# Altium schematic sheet ARK_Flow_External_Interfaces_Power.SchDoc(ARK_Flow_External_Interfaces_Power)
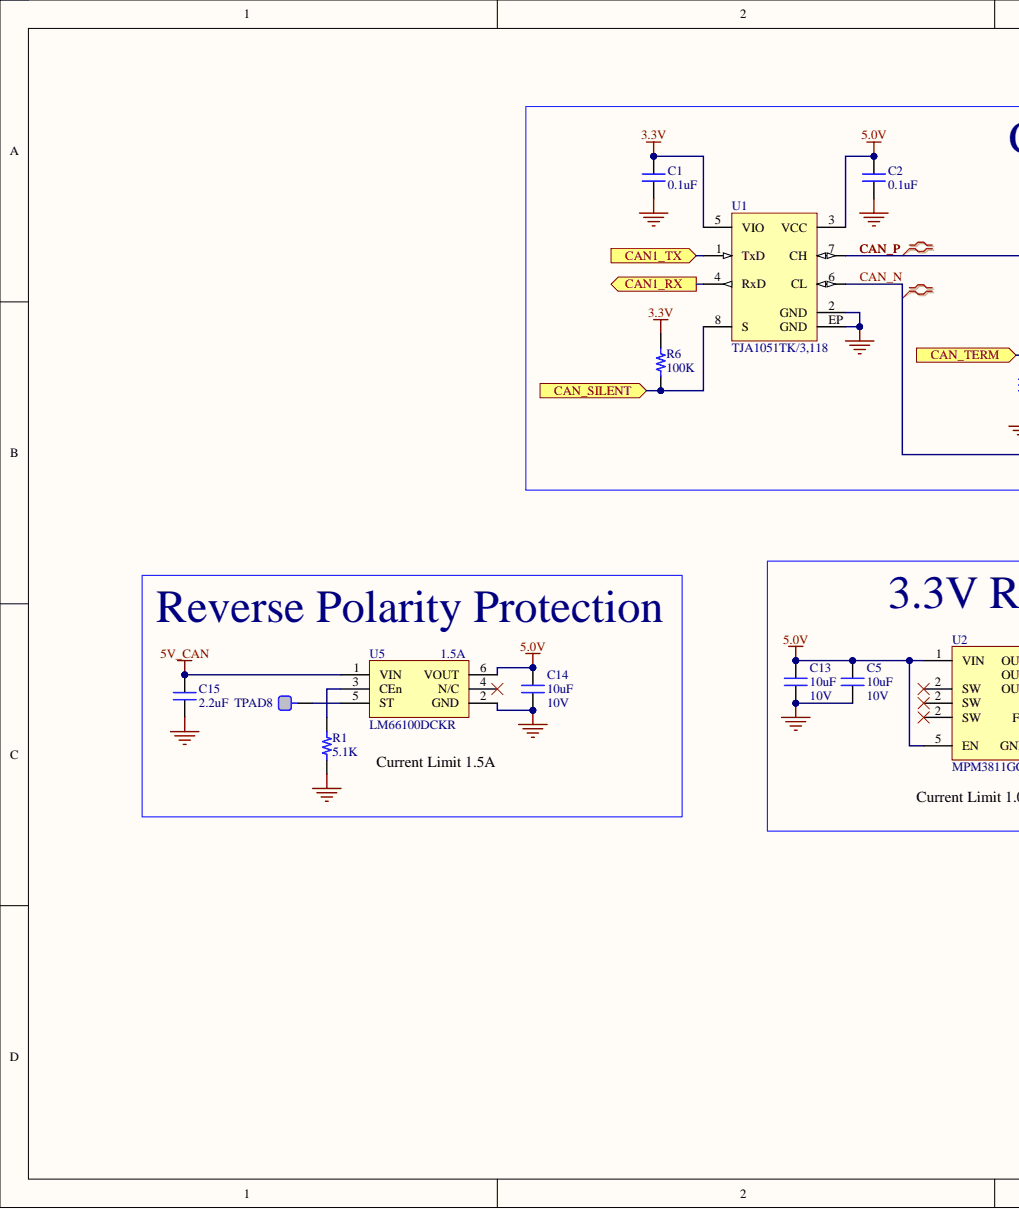
[diagram p0: ARK_Flow_External_Interfaces_Power.SchDoc, left]
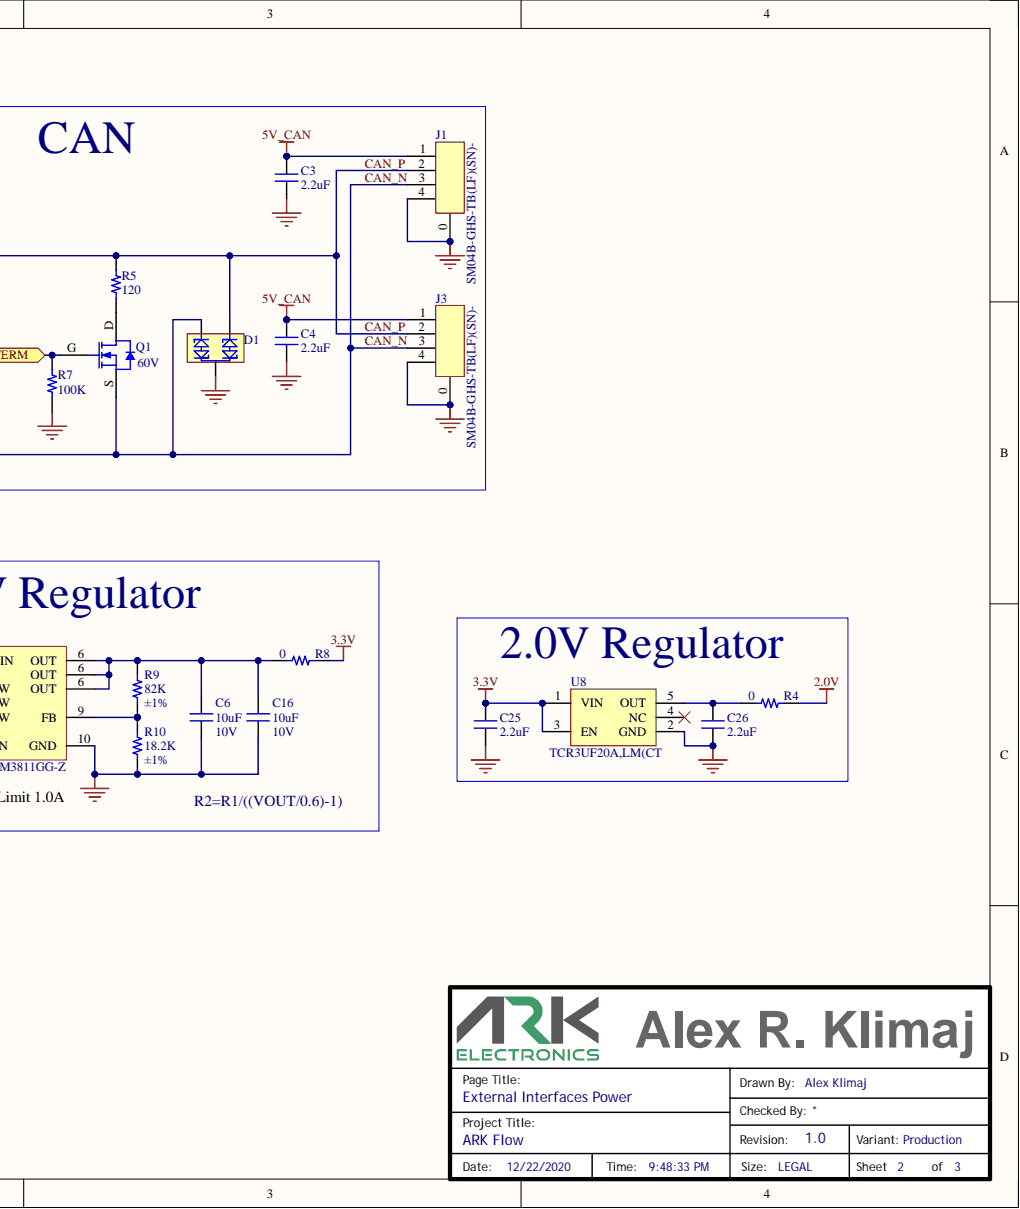
[diagram p1: ARK_Flow_External_Interfaces_Power.SchDoc, right]

## Parts
C1  value 0.1uF  [CAP 0402/1005]
C2  value 0.1uF  [CAP 0402/1005]
C3  value 2.2uF  [CAP 0402/1005]  (hidden comment: Capacitor)
C4  value 2.2uF  [CAP 0402/1005]  (hidden comment: Capacitor)
C5  10uF  Voltage_Rating: 10V  [CAP 0402/1005]
C6  10uF  Voltage_Rating: 10V  [CAP 0402/1005]
C13  10uF  Voltage_Rating: 10V  [CAP 0402/1005]
C14  10uF  Voltage_Rating: 10V  [CAP 0402/1005]
C15  value 2.2uF  [CAP 0402/1005]  (hidden comment: Capacitor)
C16  10uF  Voltage_Rating: 10V  [CAP 0402/1005]
C25  value 2.2uF  [CAP 0402/1005]  (hidden comment: Capacitor)
C26  value 2.2uF  [CAP 0402/1005]  (hidden comment: Capacitor)
D1  PESD1CAN-UX  [SOT65P210X110-3N]  (value not drawn)
J1  value SM04B-GHS-TB(LF)(SN)-  Mfr Part Number: SM04B-GHS-TB(LF)(SN)-  [SM04B-GHS]
J3  value SM04B-GHS-TB(LF)(SN)-  Mfr Part Number: SM04B-GHS-TB(LF)(SN)-  [SM04B-GHS]
Q1  Voltage_Rating: 60V  [SOT90P240X110-3N]  (hidden comment: DMN67D7L-7)
R1  value 5.1K  [RESC0402(1005)_N]
R4  0  [RESC0402(1005)_N]
R5  120  [RESC0402(1005)_N]
R6  100K  [RESC0402(1005)_N]
R7  100K  [RESC0402(1005)_N]
R8  0  [RESC0402(1005)_N]
R9  82K  Tolerance: ±1%  [RESC0402(1005)_N]
R10  18.2K  Tolerance: ±1%  [RESC0402(1005)_N]
TPAD8  (no value)  [TEST_PAD]  (no BOM)
U1  TJA1051TK/3,118  [8DFN_3x3]
U2  MPM3811GG-Z  [MPM3804]
U5  value LM66100DCKR  Current_Rating: 1.5A  Mfr Part Number: LM66100DCKR  [SOT65P210X110-6N]
U8  value TCR3UF20A,LM(CT  Mfr Part Number: TCR3UF20A,LM(CT  [DIODES SOT25]

## Ports
CAN1_RX, CAN1_TX, CAN_SILENT, CAN_TERM

## Nets
2.0V: R4.1
3.3V: C1.2 C25.2 R6.2 R8.1 U1.5(VIO) U8.1(VIN) U8.3(EN)
5.0V: C2.2 C3.2 C4.2 C5.2 C13.2 C14.2 C15.2 J1.1 J3.1 U1.3(VCC) U2.1(VIN) U2.5(EN) U5.1(VIN) U5.6(VOUT)
GND: C1.1 C2.1 C3.1 C4.1 C5.1 C6.1 C13.1 C14.1 C15.1 C16.1 C25.1 C26.1 D1.3 J1.0 J1.4 J3.0 J3.4 R1.2 R7.1 R10.1 U1.2(GND) U1.EP(GND) U2.10(GND) U5.2(GND) U8.2(GND)
CAN1_RX: U1.4(RxD) ; port CAN1_RX
CAN1_TX: U1.1(TxD) ; port CAN1_TX
CAN_N: D1.1 J1.3 J3.3 Q1.S U1.6(CL)
CAN_P: D1.2 J1.2 J3.2 R5.2 U1.7(CH)
CAN_SILENT: R6.1 U1.8(S) ; port CAN_SILENT
CAN_TERM: Q1.G R7.2 ; port CAN_TERM
NetC6_2: C6.2 C16.2 R8.2 R9.2 U2.6(OUT)
NetC26_2: C26.2 R4.2 U8.5(OUT)
NetQ1_D: Q1.D R5.1
NetR1_1: R1.1 U5.3(CEn)
NetR9_1: R9.1 R10.2 U2.9(FB)
NetTPAD8_1: TPAD8.1 U5.5(ST)

Not connected: U2 2(SW); U5 4(N/C); U8 4(NC)

## Library data of the parts
C1 C2 = 30007: SMT Capacitor; Manufacturer: Murata; Mfr Part Description: CAP CER 0.1uF ±10% 25V X5R 0402 (1005 Metric); Mfr Part Number: GRM155R61E104KA87D; Database Table Name: Parts; Dielectric: X5R; Tolerance: ±10%; Updated By: aklimaj; Voltage_Rating: 25V; Component Kind: Standard; ComponentLink1Description: Datasheet; ComponentLink1URL: http://search.murata.co.jp/Ceramy/image/img/A01X/partnumbering_e_01.pdf; ComponentLink2Description: Supplier Part Details; ComponentLink2URL: https://www.digikey.com/product-detail/en/murata-electronics-north-america/GRM155R61E104KA87D/490-5920-1-ND/3721277; Created_Date: 2017/07/11 17:31:38; ID: 30007; Library Name: Parts.DbLib; LifeCycle: New; Package_Case: 0402 (1005 Metric); Part_Type: Capacitor; RoHS: Yes; Supplier: Digi-Key; Supplier Part Number: 490-5920-1-ND; Temperature Range: -55C to +85C; Updated_Date: 2017/07/11 17:32:00; Created By: aklimaj
C3 C4 C15 C25 C26 = 30151: SMT Capacitor; Manufacturer: Murata; Mfr Part Description: CAP CER 2.2uF ±20% 10V X5R 0402 (1005 Metric); Mfr Part Number: GRM155R61A225ME95D; Database Table Name: Parts; Dielectric: X5R; Tolerance: ±20%; Updated By: aklimaj; Voltage_Rating: 10V; Component Kind: Standard; ComponentLink1Description: Datasheet; ComponentLink1URL: http://search.murata.co.jp/Ceramy/image/img/A01X/partnumbering_e_01.pdf; ComponentLink2Description: Supplier Part Details; ComponentLink2URL: https://www.digikey.com/product-detail/en/murata-electronics-north-america/GRM155R61A225ME95D/490-10452-2-ND/5027537; Created_Date: 2017/11/02 14:41:38; ID: 30151; Library Name: Parts.DbLib; LifeCycle: New; Package_Case: 0402 (1005 Metric); Part_Type: Capacitor; RoHS: Yes; Supplier: Digi-Key; Supplier Part Number: 490-10452-2-ND; Temperature Range: -55C to +85C; Updated_Date: 2018/10/19 21:38:16; Created By: aklimaj
C5 C6 C13 C14 C16 = 30418: SMT Capacitor; Manufacturer: Samsung Electro-Mechanics; Mfr Part Description: CAP CER 10uF ±20% 10V X5R 0402 (1005 Metric); Mfr Part Number: CL05A106MP8NUB8; Database Table Name: Parts; Dielectric: X5R; Tolerance: ±20%; Updated By: aklimaj; Component Kind: Standard; ComponentLink1Description: Datasheet; ComponentLink1URL: http://www.samsungsem.com/kr/support/product-search/mlcc/CL05A106MP8NUB8.jsp; ComponentLink2Description: Supplier Part Details; ComponentLink2URL: https://www.digikey.com/product-detail/en/samsung-electro-mechanics/CL05A106MP8NUB8/1276-6830-1-ND/5961689; Created_Date: 2019/04/03 13:12:18; ID: 30418; Library Name: Parts.DbLib; LifeCycle: New; Package_Case: 0402 (1005 Metric); Part_Type: Capacitor; RoHS: Yes; Supplier: Digi-Key; Supplier Part Number: 1276-6830-1-ND; Temperature Range: -55C to +85C; Updated_Date: 2019/04/03 13:12:36; Value: 10uF; Created By: aklimaj
D1 = 30765: Manufacturer: Nexperia USA Inc.; Mfr Part Description: TVS DIODE 24V 50V SC70-3; Part_Type: Protection; Current_Rating: 3A (8/20µs); Database Table Name: Parts; Updated By: aklimaj; Voltage_Rating: 24V (Max); Component Kind: Standard; ComponentLink1Description: Datasheet; ComponentLink1URL: https://assets.nexperia.com/documents/data-sheet/PESD1CAN-U.pdf; ComponentLink2Description: Supplier Part Details; ComponentLink2URL: https://www.digikey.com/product-detail/en/nexperia-usa-inc/PESD1CAN-UX/1727-1306-1-ND/4386316; Created_Date: 2020/04/14 12:26:16; ID: 30765; Library Name: Parts.DbLib; LifeCycle: New; Mfr Part Number: PESD1CAN-UX; Package_Case: SC-70, SOT-323; RoHS: Yes; Supplier: Digi-Key; Supplier Part Number: 1727-1306-1-ND; Temperature Range: -55C to +150C (TA); Updated_Date: 2020/04/14 12:34:02; Value: PESD1CAN-UX; Created By: aklimaj
J1 J3 = 30106: CONN HEADER GH SIDE 4POS 1.25MM; Manufacturer: JST Sales America Inc.; Part_Type: Connector; Current_Rating: 1A; Database Table Name: Parts; Updated By: aklimaj; Voltage_Rating: 50V; Component Kind: Standard; ComponentLink1Description: Datasheet; ComponentLink1URL: http://www.jst-mfg.com/product/pdf/eng/eGH.pdf; ComponentLink2Description: Supplier Part Details; ComponentLink2URL: https://www.digikey.com/product-detail/en/jst-sales-america-inc/SM04B-GHS-TB-LF-SN/455-1566-1-ND/807834; Created_Date: 2017/09/21 14:10:07; ID: 30106; Library Name: Parts.DbLib; LifeCycle: New; Mfr Part Description: CONN HEADER GH SIDE 4POS 1.25MM; RoHS: Yes; Supplier: Digi-Key; Supplier Part Number: 455-1566-1-ND; Temperature Range: -25C to +85C; Updated_Date: 2018/10/19 21:38:27; Created By: aklimaj
Q1 = 30561: Manufacturer: Diodes Incorporated; Mfr Part Description: N-Channel 60V 210mA (Ta) 570mW (Ta) Surface Mount SOT-23-3; Part_Type: Discrete; Current_Rating: 210mA (Ta); Database Table Name: Parts; Notes: Vgs max = +-40V; Updated By: aklimaj; Component Kind: Standard; ComponentLink1Description: Datasheet; ComponentLink1URL: https://www.diodes.com/assets/Datasheets/DMN67D7L.pdf; ComponentLink2Description: Supplier Part Details; ComponentLink2URL: https://www.digikey.com/product-detail/en/diodes-incorporated/DMN67D7L-7/DMN67D7L-7DICT-ND/8126144; Created_Date: 2019/10/13 13:46:33; ID: 30561; Library Name: Parts.DbLib; LifeCycle: New; Mfr Part Number: DMN67D7L-7; Package_Case: TO-236-3, SC-59, SOT-23-3; RoHS: Yes; Supplier: Digi-Key; Supplier Part Number: DMN67D7L-7DICT-ND; Temperature Range: -55C to +150C (TJ); Updated_Date: 2019/10/13 13:52:50; Value: DMN67D7L-7; Created By: aklimaj
R1 = 30207: SMT Resistor; Manufacturer: Yageo; Mfr Part Description: RES 5.1K Ohm ±1% 1/16W 0402 (1005 Metric); Mfr Part Number: RC0402FR-075K1L; Database Table Name: Parts; Power_Rating: 0.063W, 1/16W; Temperature Coeff: ±100ppm/degC; Tolerance: ±1%; Updated By: aklimaj; Component Kind: Standard; ComponentLink1Description: Datasheet; ComponentLink1URL: http://www.yageo.com/documents/recent/PYu-RC_Group_51_RoHS_L_9.pdf; ComponentLink2Description: Supplier Part Details; ComponentLink2URL: https://www.digikey.com/product-detail/en/yageo/RC0402FR-075K1L/311-5.10KLRCT-ND/729571; Created_Date: 2018/05/21 18:16:47; ID: 30207; Library Name: Parts.DbLib; LifeCycle: New; Package_Case: 0402 (1005 Metric); Part_Type: Resistor; RoHS: Yes; Supplier: Digi-Key; Supplier Part Number: 311-5.10KLRCT-ND; Temperature Range: -55C to +155C; Updated_Date: 2018/10/19 21:38:02; Created By: aklimaj
R4 R8 = 30327: SMT Resistor; Manufacturer: Yageo; Mfr Part Description: RES 0 Ohm Jumper 1/16W 0402 (1005 Metric); Mfr Part Number: AC0402JR-070RL; Database Table Name: Parts; Power_Rating: 0.063W, 1/16W; Tolerance: Jumper; Updated By: aklimaj; Component Kind: Standard; ComponentLink1Description: Datasheet; ComponentLink1URL: http://www.yageo.com/NewPortal/yageodocoutput?fileName=/pdf/R-Chip/PYu-AC_51_RoHS_L_6.pdf; ComponentLink2Description: Supplier Part Details; ComponentLink2URL: https://www.digikey.com/products/en?keywords=311-0.0LBCT-ND&stock=1; Created_Date: 2019/02/28 18:42:38; ID: 30327; Library Name: Parts.DbLib; LifeCycle: New; Package_Case: 0402 (1005 Metric); Part_Type: Resistor; RoHS: Yes; Supplier: Digi-Key; Supplier Part Number: 311-0.0LBCT-ND; Temperature Range: -55C to +155C; Updated_Date: 2019/02/28 18:42:52; Value: 0; Created By: aklimaj
R5 = 30315: SMT Resistor; Manufacturer: Yageo; Mfr Part Description: RES 120 Ohm ±1% 1/16W 0402 (1005 Metric); Mfr Part Number: AC0402FR-07120RL; Database Table Name: Parts; Power_Rating: 0.063W, 1/16W; Temperature Coeff: ±100ppm/degC; Tolerance: ±1%; Updated By: aklimaj; Component Kind: Standard; ComponentLink1Description: Datasheet; ComponentLink1URL: http://www.yageo.com/NewPortal/yageodocoutput?fileName=/pdf/R-Chip/PYu-AC_51_RoHS_L_6.pdf; ComponentLink2Description: Supplier Part Details; ComponentLink2URL: https://www.digikey.com/products/en?keywords=YAG3440CT-ND&stock=1; Created_Date: 2019/02/28 17:45:49; ID: 30315; Library Name: Parts.DbLib; LifeCycle: New; Package_Case: 0402 (1005 Metric); Part_Type: Resistor; RoHS: Yes; Supplier: Digi-Key; Supplier Part Number: YAG3440CT-ND; Temperature Range: -55C to +155C; Updated_Date: 2019/02/28 17:46:09; Value: 120; Created By: aklimaj
R6 R7 = 30333: SMT Resistor; Manufacturer: Yageo; Mfr Part Description: RES 100K Ohm ±5% 1/16W 0402 (1005 Metric); Mfr Part Number: AC0402JR-07100KL; Database Table Name: Parts; Power_Rating: 0.063W, 1/16W; Temperature Coeff: ±100ppm/degC; Tolerance: ±5%; Updated By: aklimaj; Component Kind: Standard; ComponentLink1Description: Datasheet; ComponentLink1URL: http://www.yageo.com/NewPortal/yageodocoutput?fileName=/pdf/R-Chip/PYu-AC_51_RoHS_L_6.pdf; ComponentLink2Description: Supplier Part Details; ComponentLink2URL: https://www.digikey.com/products/en?keywords=YAG3515CT-ND&stock=1; Created_Date: 2019/02/28 18:55:55; ID: 30333; Library Name: Parts.DbLib; LifeCycle: New; Package_Case: 0402 (1005 Metric); Part_Type: Resistor; RoHS: Yes; Supplier: Digi-Key; Supplier Part Number: YAG3515CT-ND; Temperature Range: -55C to +155C; Updated_Date: 2019/02/28 18:56:10; Value: 100K; Created By: aklimaj
R9 = 30610: SMT Resistor; Manufacturer: Yageo; Mfr Part Description: RES 82K Ohm ±1% 1/16W 0402 (1005 Metric); Mfr Part Number: RC0402FR-0782KL; Database Table Name: Parts; Power_Rating: 0.063W, 1/16W; Temperature Coeff: ±100ppm/degC; Updated By: aklimaj; Component Kind: Standard; ComponentLink1Description: Datasheet; ComponentLink1URL: https://www.yageo.com/upload/media/product/productsearch/datasheet/rchip/PYu-RC_Group_51_RoHS_L_10.pdf; ComponentLink2Description: Supplier Part Details; ComponentLink2URL: https://www.digikey.com/product-detail/en/yageo/RC0402FR-0782KL/311-82.0KLRCT-ND/729612; Created_Date: 2019/11/09 17:33:52; ID: 30610; Library Name: Parts.DbLib; LifeCycle: New; Package_Case: 0402 (1005 Metric); Part_Type: Resistor; RoHS: Yes; Supplier: Digi-Key; Supplier Part Number: 311-82.0KLRCT-ND; Temperature Range: -55C to +155C; Updated_Date: 2019/11/09 17:34:32; Value: 82K; Created By: aklimaj
R10 = 30800: SMT Resistor; Manufacturer: Yageo; Mfr Part Description: RES 18.2K Ohm ±1% 1/16W 0402 (1005 Metric); Mfr Part Number: RC0402FR-0718K2L; Database Table Name: Parts; Power_Rating: 0.063W, 1/16W; Temperature Coeff: ±100ppm/degC; Updated By: aklimaj; Component Kind: Standard; ComponentLink1Description: Datasheet; ComponentLink1URL: https://www.yageo.com/upload/media/product/productsearch/datasheet/rchip/PYu-RC_Group_51_RoHS_L_10.pdf; ComponentLink2Description: Supplier Part Details; ComponentLink2URL: https://www.digikey.com/product-detail/en/yageo/RC0402FR-0718K2L/YAG2371CT-ND/5281237; Created_Date: 2020/06/16 15:20:02; ID: 30800; Library Name: Parts.DbLib; LifeCycle: New; Package_Case: 0402 (1005 Metric); Part_Type: Resistor; RoHS: Yes; Supplier: Digi-Key; Supplier Part Number: YAG2371CT-ND; Temperature Range: -55C to +155C; Updated_Date: 2020/06/16 15:20:07; Value: 18.2K; Created By: aklimaj
TPAD8 = 30015: TEST_PAD; Mfr Part Description: Test Point; Database Table Name: Parts; Updated By: aklimaj; ComponentLink1Description: Datasheet; ComponentLink2Description: Supplier Part Details; Created_Date: 2017/07/13 09:26:35; ID: 30015; Library Name: Parts.DbLib; LifeCycle: New; Part_Type: Test Point; RoHS: Yes; Updated_Date: 2019/07/19 12:21:04; Created By: aklimaj
U1 = 30095: IC CAN TRANSEIVER HS 8HVSON; Manufacturer: NXP USA Inc.; Database Table Name: Parts; Updated By: aklimaj; Component Kind: Standard; ComponentLink1Description: Datasheet; ComponentLink1URL: http://www.nxp.com/documents/data_sheet/TJA1051.pdf; ComponentLink2Description: Supplier Part Details; ComponentLink2URL: https://www.digikey.com/product-detail/en/nxp-usa-inc/TJA1051TK-3,118/568-8686-1-ND/2803572; Created_Date: 2017/09/13 12:36:12; ID: 30095; Library Name: Parts.DbLib; LifeCycle: New; Mfr Part Description: IC CAN TRANSEIVER HS 8HVSON; Mfr Part Number: TJA1051TK/3,118; Package_Case: 8-VDFN Exposed Pad; Part_Type: IC; RoHS: Yes; Supplier: Digi-Key; Supplier Part Number: 568-8686-1-ND; Temperature Range: -40C to +150C; Updated_Date: 2018/10/19 21:38:29; Value: TJA1051TK/3,118; Created By: aklimaj
U2 = 30604: Manufacturer: Monolithic Power Systems Inc.; Mfr Part Description: Non-Isolated PoL Module DC DC Converter 1 Output 0.6 ~ 1.2V 1A 2.3V - 5.5V Input; Part_Type: Non-Isolated; Current_Rating: 1A; Database Table Name: Parts; Updated By: aklimaj; Voltage_Rating: 0.6 ~ 1.2V; Component Kind: Standard; ComponentLink1Description: Datasheet; ComponentLink1URL: https://media.monolithicpower.com/document/m/p/mpm3811_r1.0.pdf; ComponentLink2Description: Supplier Part Details; ComponentLink2URL: https://www.digikey.com/product-detail/en/monolithic-power-systems-inc/MPM3811GG-Z/1589-1958-1-ND/9644348; Created_Date: 2019/11/09 12:52:24; ID: 30604; Library Name: Parts.DbLib; LifeCycle: New; Mfr Part Number: MPM3811GG-Z; Package_Case: 10-PowerVFQFN Module; Supplier: Digi-Key; Supplier Part Number: 1589-1958-1-ND; Temperature Range: -40C to +125C; Updated_Date: 2019/11/09 13:05:57; Value: MPM3811GG-Z; Created By: aklimaj
U5 = 30951: Manufacturer: Texas Instruments; Mfr Part Description: IC OR CTRLR IDEAL DIODE SC70-6; Part_Type: IC; Database Table Name: Parts; Updated By: aklimaj; Voltage_Rating: 5.5V; Component Kind: Standard; ComponentLink1Description: Datasheet; ComponentLink1URL: http://www.ti.com/general/docs/suppproductinfo.tsp?distId=10&amp;gotoUrl=http%3A%2F%2Fwww.ti.com%2Flit%2Fgpn%2flm66100; ComponentLink2Description: Supplier Part Details; ComponentLink2URL: https://www.digikey.com/products/en?keywords=LM66100DCKR; Created_Date: 2020/12/22 17:48:20; ID: 30951; Library Name: Parts.DbLib; LifeCycle: New; Package_Case: 6-TSSOP, SC-88, SOT-363; RoHS: Yes; Supplier: Digi-Key; Supplier Part Number: 296-53541-2-ND; Temperature Range: -40C to +105C; Updated_Date: 2020/12/22 17:53:43; Created By: aklimaj
U8 = 30955: IC REG LDO 3.3V 0.25A SOT23-5; Manufacturer: Toshiba Semiconductor and Storage; Mfr Part Description: (LDO) REG, VOUT: 2.0V IOUT: 300M; Database Table Name: Parts; Updated By: aklimaj; Component Kind: Standard; ComponentLink1Description: Datasheet; ComponentLink1URL: https:/en/supplier-centers/toshiba-semiconductor-and-storage; ComponentLink2Description: Supplier Part Details; ComponentLink2URL: https://www.digikey.com/products/en?keywords=TCR3UF20A,LM(CT; Created_Date: 2020/12/22 21:20:06; ID: 30955; Library Name: Parts.DbLib; LifeCycle: New; Package_Case: SC-74A, SOT-753; Part_Type: IC; Supplier: Digi-Key; Supplier Part Number: 264-TCR3UF20ALM(TR-ND; Temperature Range: -40C to +85C; Updated_Date: 2020/12/22 21:24:50; Created By: aklimaj
